# Altium schematic sheet PowerReg.SchDoc
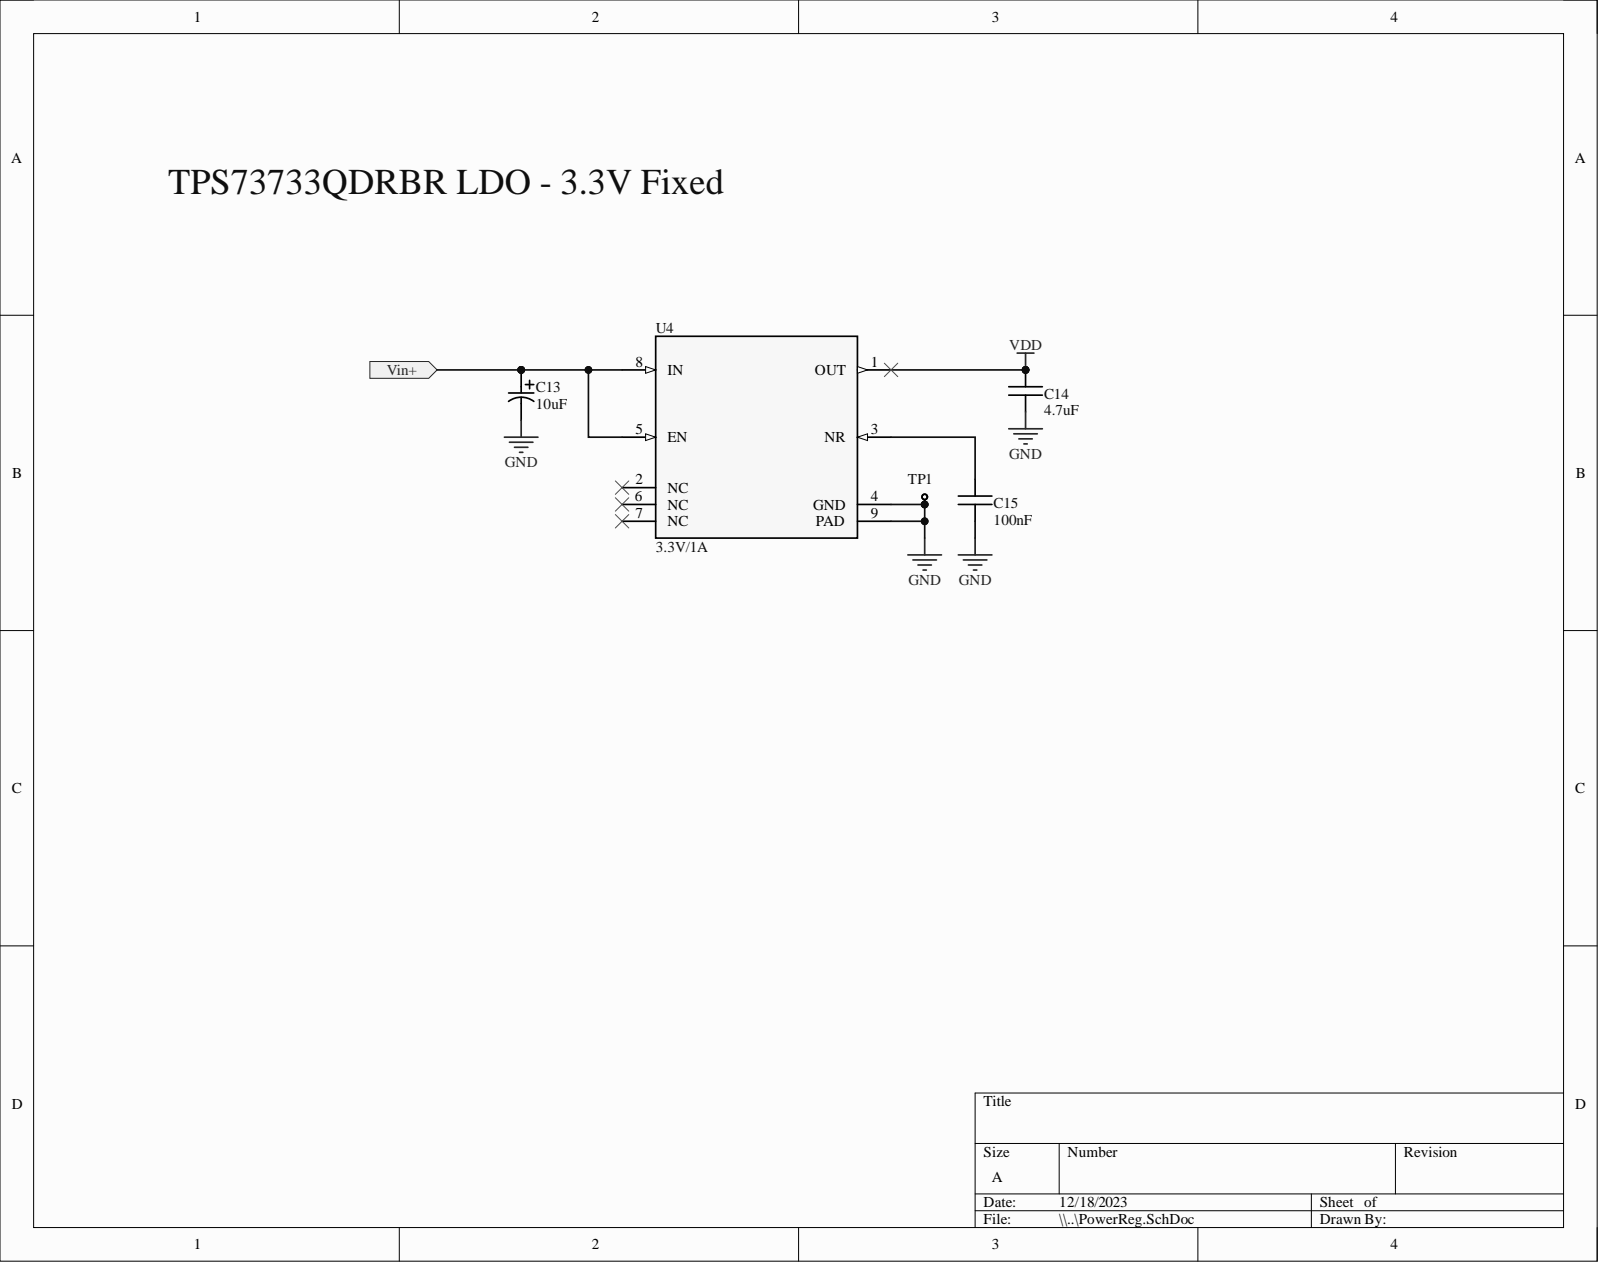
[diagram p0: PowerReg.SchDoc]

## Parts
C13  10uF  [CAP1206_TAJA]
C14  4.7uF  [CAP0805]
C15  100nF  [CAP0805]
TP1  1mm Test Point  [TP_1MM]  (value not drawn)
U4  3.3V/1A  [VSON_DRB8]

## Ports
Vin+

## Nets
GND: C13.2 C14.1 C15.2 TP1.1 U4.4(GND) U4.9(PAD)
VDD: C14.2 U4.1(OUT)
Vin+: C13.1 U4.5(EN) U4.8(IN) ; port Vin+
NetC15_1: C15.1 U4.3(NR)

Not connected: U4 2(NC) 6(NC) 7(NC)

## Library data of the parts
C13 = TAJA106K016RNJ: Cap Tant Solid 10uF 16V A CASE 10%( 3.2 X 1.6 X 1.6mm) Inward L SMD 3216-18 3 Ohm 125C T/R; Manufacturer: Kyocera AVX; Dielectric Material: Tantalum; Dissipation Factor: 8%; ESR (Equivalent Series Resistance): 3R; Frequency: 300MHz; Leakage Current: 1.6uA; Life (Hours): 2000Hour; Polarity: Polar; Ripple Current: 158mA; Type: DEV; Value: 10uF 16V 10% Tantalum; Case Code (Imperial): 1206; Case Code (Metric): 3216; Case/Package: 1206; Category: Tantalum Capacitors; Component Kind: Standard; ComponentLink1Description: https://datasheet.ciiva.com/27051/1234933-27051768.pdf?src-supplier=Jameco; ComponentLink1URL: https://datasheet.ciiva.com/27051/1234933-27051768.pdf?src-supplier=Jameco; ComponentLink2Description: https://datasheet.ciiva.com/26341/559411-da-01-en-tacc-a-3-2-mm-x-1-6-mm-x-1-6-mm-10-uf-26341755.pdf?src-supplier=Conrad; ComponentLink2URL: https://datasheet.ciiva.com/26341/559411-da-01-en-tacc-a-3-2-mm-x-1-6-mm-x-1-6-mm-10-uf-26341755.pdf?src-supplier=Conrad; ComponentLink3Description: https://datasheet.ciiva.com/18710/taj-eng-18710622.pdf?src-supplier=Distrelec; ComponentLink3URL: https://datasheet.ciiva.com/18710/taj-eng-18710622.pdf?src-supplier=Distrelec; ComponentLink4Description: https://datasheet.ciiva.com/7730/1703059-7730712.pdf?src-supplier=Element14; ComponentLink4URL: https://datasheet.ciiva.com/7730/1703059-7730712.pdf?src-supplier=Element14; ComponentLink5Description: https://datasheet.ciiva.com/7730/1703059-7730713.pdf?src-supplier=Element14; ComponentLink5URL: https://datasheet.ciiva.com/7730/1703059-7730713.pdf?src-supplier=Element14; ComponentLink6Description: https://datasheet.ciiva.com/7730/taj-7730975.pdf?src-supplier=Element14; ComponentLink6URL: https://datasheet.ciiva.com/7730/taj-7730975.pdf?src-supplier=Element14; ComponentLink7Description: https://datasheet.ciiva.com/7730/1680476-7730714.pdf?src-supplier=Element14; ComponentLink7URL: https://datasheet.ciiva.com/7730/1680476-7730714.pdf?src-supplier=Element14; ComponentLink8Description: https://datasheet.ciiva.com/263/taj-263392.pdf?src-supplier=Digi-Key; ComponentLink8URL: https://datasheet.ciiva.com/263/taj-263392.pdf?src-supplier=Digi-Key; ComponentLink9Description: https://datasheet.ciiva.com/263/taj-263391.pdf?src-supplier=Digi-Key; ComponentLink9URL: https://datasheet.ciiva.com/263/taj-263391.pdf?src-supplier=Digi-Key; ComponentLink10Description: https://datasheet.ciiva.com/263/taj-263393.pdf?src-supplier=Digi-Key; ComponentLink10URL: https://datasheet.ciiva.com/263/taj-263393.pdf?src-supplier=Digi-Key; ComponentLink11Description: https://datasheet.ciiva.com/12882/taj-27497-12882509.pdf?src-supplier=Mouser; ComponentLink11URL: https://datasheet.ciiva.com/12882/taj-27497-12882509.pdf?src-supplier=Mouser; ComponentLink12Description: https://datasheet.ciiva.com/3004/taj-3004388.pdf?src-supplier=Avnet; ComponentLink12URL: https://datasheet.ciiva.com/3004/taj-3004388.pdf?src-supplier=Avnet; ComponentLink13Description: https://datasheet.ciiva.com/16379/taj-16379015.pdf?src-supplier=Future+Electronics; ComponentLink13URL: https://datasheet.ciiva.com/16379/taj-16379015.pdf?src-supplier=Future+Electronics; ComponentLink14Description: https://datasheet.ciiva.com/3004/taj-3004387.pdf?src-supplier=Avnet; ComponentLink14URL: https://datasheet.ciiva.com/3004/taj-3004387.pdf?src-supplier=Avnet; Construction: Flat; Depth: 1.6mm; Features: General Purpose; Height: 1.6mm; Height - Seated (Max): 0.071inch; LCSC Part#: C7171; Lead Free: Lead Free; Lead Pitch: 1.1mm; Length: 3.2mm; Library Name: Schlib1.SchLib; Manufacturer Part Number: TAJA106K016RNJ; Manufacturer_Part_Number: TAJA106K016RNJ; Max Operating Temperature: 125°C; Mfr_Name: KYOCERA AVX; Min Operating Temperature: -55°C; Mounting Technology: Surface Mount,PCB; Package Quantity: 1; Packaging: Tape and Reel; Pins: 2; Pricing: 1=0.35, 10=0.234, 50=0.1726, 100=0.1405, 500=0.1109, 1000=0.09365 (USD); Radiation Hardening: No; Reach SVHC Compliant: No; RefDes: RefDes; RoHS: Yes; RoHS Compliant: Yes; Series: TAJ; Size: 1.6mm; Stock: 8878; Supplier: Digi-Key; Supplier Part Number: 478-1655-6-ND; Termination: SMD/SMT; Tolerance: 10%; Voltage: 16V; Voltage Rating: 16V; Voltage Rating (AC): 16V; Voltage Rating (DC): 16V; Width: 1.6mm; Copyright: Copyright (C) 2023 Ultra Librarian. All rights reserved.
C14 = CL21A475KAQNNNE: Multilayer Ceramic Capacitor, 4.7 uF, 25 V, ± 10%, X5R, 0805 [2012 Metric]; Manufacturer: Samsung; Value: 4.7uF 25V; Voltage Rating: 25V; Case Code (Imperial): 0805; Case Code (Metric): 2012; Case/Package: 0805; Category: Ceramic Capacitors; Component Kind: Standard; ComponentLink1Description: https://datasheet.ciiva.com/25798/cl21a475kaqnnne-25798882.pdf?src-supplier=Digi-Key; ComponentLink1URL: https://datasheet.ciiva.com/25798/cl21a475kaqnnne-25798882.pdf?src-supplier=Digi-Key; Construction: Flat; Depth: 1.25mm; Dielectric: X5R; Height: 1.25mm; LCSC Part#: C1779; Lead Free: Lead Free; Length: 2mm; Library Name: Schlib1.SchLib; Manufacturer Part Number: CL21A475KAQNNNE; Material: Ceramic; Max Operating Temperature: 85°C; Min Operating Temperature: -55°C; Mounting Technology: Surface Mount; Packaging: Tape and Reel; Pricing: 1=0.1, 10=0.069, 50=0.0414, 100=0.0326, 500=0.02408, 1000=0.01994 (USD); Radiation Hardening: No; RoHS: Yes; RoHS Compliant: Yes; Series: CL; Stock: 3502142; Supplier: Digi-Key; Supplier Part Number: 1276-1244-1-ND; Tolerance: 10%; Voltage Rating (DC): 25V
C15 = CC0805KRX7R9BB104: Multilayer Ceramic Capacitor, 0.1 uF, 50 V, ± 10%, X7R, 0805 [2012 Metric]; Manufacturer: Yageo; Insulation Resistance: 100GR; Value: 100nF 50V; Voltage: 50V; Case Code (Imperial): 0805; Case Code (Metric): 2012; Case/Package: 0805; Category: Ceramic Capacitors; Component Kind: Standard; ComponentLink1Description: https://datasheet.ciiva.com/11786/0900766b80d84f1d-11786009.pdf?src-supplier=Rs; ComponentLink1URL: https://datasheet.ciiva.com/11786/0900766b80d84f1d-11786009.pdf?src-supplier=Rs; ComponentLink2Description: https://datasheet.ciiva.com/46393/1734573-46393307.pdf?src-supplier=Element14; ComponentLink2URL: https://datasheet.ciiva.com/46393/1734573-46393307.pdf?src-supplier=Element14; ComponentLink3Description: https://datasheet.ciiva.com/155/mlccorderingcode-155930.pdf?src-supplier=Digi-Key; ComponentLink3URL: https://datasheet.ciiva.com/155/mlccorderingcode-155930.pdf?src-supplier=Digi-Key; ComponentLink4Description: https://datasheet.ciiva.com/155/mlccorderingcode-155929.pdf?src-supplier=Digi-Key; ComponentLink4URL: https://datasheet.ciiva.com/155/mlccorderingcode-155929.pdf?src-supplier=Digi-Key; ComponentLink5Description: https://datasheet.ciiva.com/155/mlccorderingcode-155931.pdf?src-supplier=Digi-Key; ComponentLink5URL: https://datasheet.ciiva.com/155/mlccorderingcode-155931.pdf?src-supplier=Digi-Key; ComponentLink6Description: https://datasheet.ciiva.com/11464/upy-gphc-x7r-6-3v-to-50v-8-11464757.pdf?src-supplier=Avnet; ComponentLink6URL: https://datasheet.ciiva.com/11464/upy-gphc-x7r-6-3v-to-50v-8-11464757.pdf?src-supplier=Avnet; ComponentLink7Description: https://datasheet.ciiva.com/28450/1489800-28450805.pdf?src-supplier=Element14; ComponentLink7URL: https://datasheet.ciiva.com/28450/1489800-28450805.pdf?src-supplier=Element14; ComponentLink8Description: https://datasheet.ciiva.com/29242/445210-da-01-en-kerko-chip-0805-x7r-0-1uf-10proz-50vg-29242074.pdf?src-supplier=Conrad; ComponentLink8URL: https://datasheet.ciiva.com/29242/445210-da-01-en-kerko-chip-0805-x7r-0-1uf-10proz-50vg-29242074.pdf?src-supplier=Conrad; Depth: 1.25mm; Dielectric: X7R; Halogen Free: Halogen Free; Height: 0.85mm; LCSC Part#: C49678; Lead Free: Lead Free; Length: 2mm; Library Name: Schlib1.SchLib; Manufacturer Part Number: CC0805KRX7R9BB104; Material: Ceramic; Max Operating Temperature: 125°C; Min Operating Temperature: -55°C; Mounting Technology: Surface Mount; Package Quantity: 4000; Packaging: Tape and Reel; Pins: 2; Pricing: 1=0.1, 10=0.07, 50=0.0418, 100=0.0329, 500=0.02428, 1000=0.0201 (USD); Radiation Hardening: No; Reach SVHC Compliant: No; RoHS: Yes; RoHS Compliant: Yes; Series: CC; Stock: 6426664; Supplier: Digi-Key; Supplier Part Number: 311-1140-1-ND; Termination: SMD/SMT; Tolerance: 10%; Voltage Rating: 50V
TP1 = TestPoint_1mm: Component Kind: Standard; Library Name: Schlib1.SchLib
U4 = TPS73733QDRBRQ1: Automotive 1-A, ultra-low-dropout voltage regulator with reverse current protection & enable; Manufacturer: Texas Instruments; Accuracy: 3%; Dropout Voltage: 130mV; Max Output current: 1A; Max Output Voltage: 3.3V; Max Power Dissipation: 2.5W; Max Supply Voltage: 5.5V; Min Current Limit: 1.05A; Min Input Voltage: 2.2V; Number of Outputs: 1; Output Type: Fixed; Output Voltage Accuracy: 1%; Polarity: Positive; Quiescent Current: 300uA; Case/Package: SON; Category: Voltage Regulators - Linear; Component Kind: Standard; ComponentLink1Description: https://datasheet.ciiva.com/2045/tps73719-q1-2045686.pdf?src-supplier=Digi-Key; ComponentLink1URL: https://datasheet.ciiva.com/2045/tps73719-q1-2045686.pdf?src-supplier=Digi-Key; ComponentLink2Description: https://datasheet.ciiva.com/3224/tps73733-q1-3224372.pdf?src-supplier=Avnet; ComponentLink2URL: https://datasheet.ciiva.com/3224/tps73733-q1-3224372.pdf?src-supplier=Avnet; ComponentLink3Description: https://datasheet.ciiva.com/4888/sbvs123-125968-4888332.pdf?src-supplier=Mouser; ComponentLink3URL: https://datasheet.ciiva.com/4888/sbvs123-125968-4888332.pdf?src-supplier=Mouser; ComponentLink4Description: https://datasheet.ciiva.com/14277/sbvs123-14277182.pdf?src-supplier=Avnet; ComponentLink4URL: https://datasheet.ciiva.com/14277/sbvs123-14277182.pdf?src-supplier=Avnet; Height: 1mm; LCSC Part#: C523768; Lead Free: Lead Free; Length: 3mm; Library Name: Schlib1.SchLib; Manufacturer Part Number: TPS73733QDRBRQ1; Max Operating Temperature: 125°C; Min Operating Temperature: -40°C; Min Output Voltage: 3.3V; Nominal Output Voltage: 3.3V; Number of Regulators: 1; Output Current: 1A; Output Voltage: 3.3V; Package Quantity: 1; Packaging: Tape and Reel; Pins: 8; Power Dissipation: 2.5W; Pricing: 1=2.06, 10=1.847, 25=1.7424, 100=1.4844, 250=1.3938, 500=1.21958, 1000=1.0105 (USD); Radiation Hardening: No; Reach SVHC Compliant: No; RoHS: Yes; RoHS Compliant: Yes; Series: Automotive, AEC-Q100; Stock: 24609; Supplier: Digi-Key; Supplier Part Number: 296-24157-1-ND; Thickness: 880um; Value: TPS73733QDRBRQ1; Width: 3mm
